# Altium schematic sheet Stepper Motor4.SchDoc
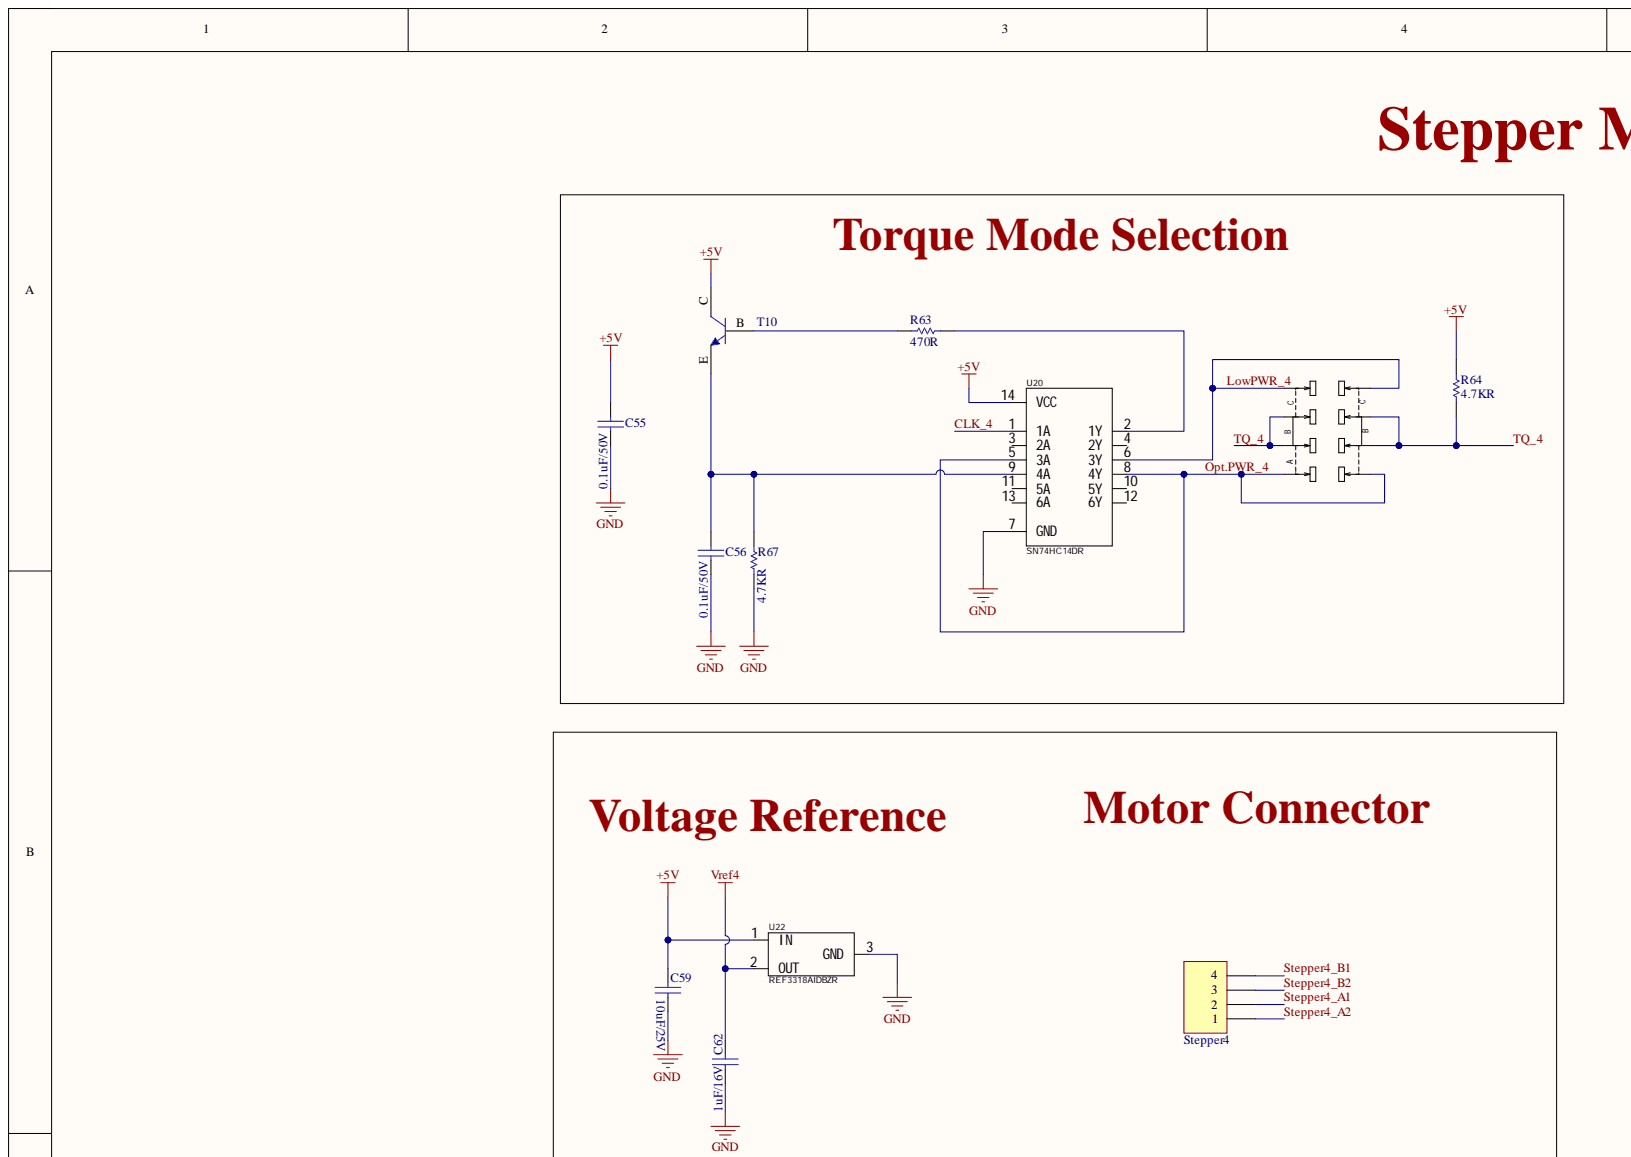
[diagram p0: Stepper Motor4.SchDoc, top left]
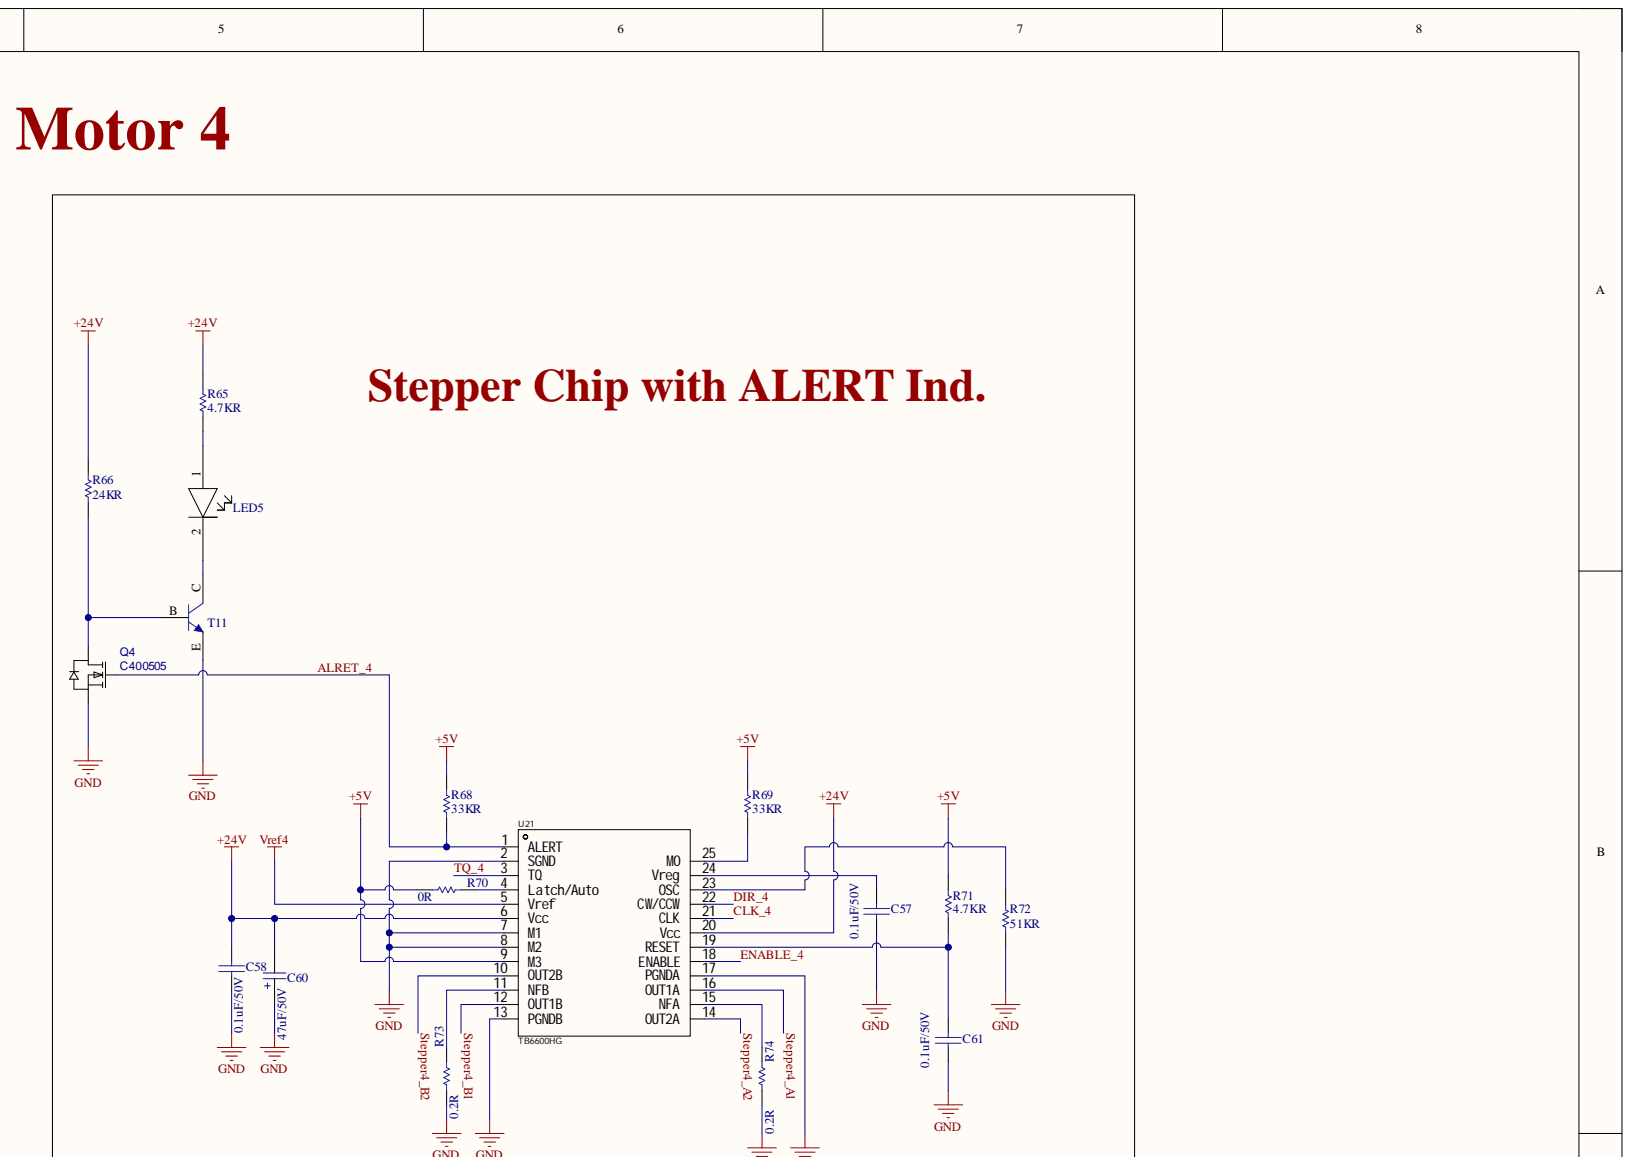
[diagram p1: Stepper Motor4.SchDoc, top right]
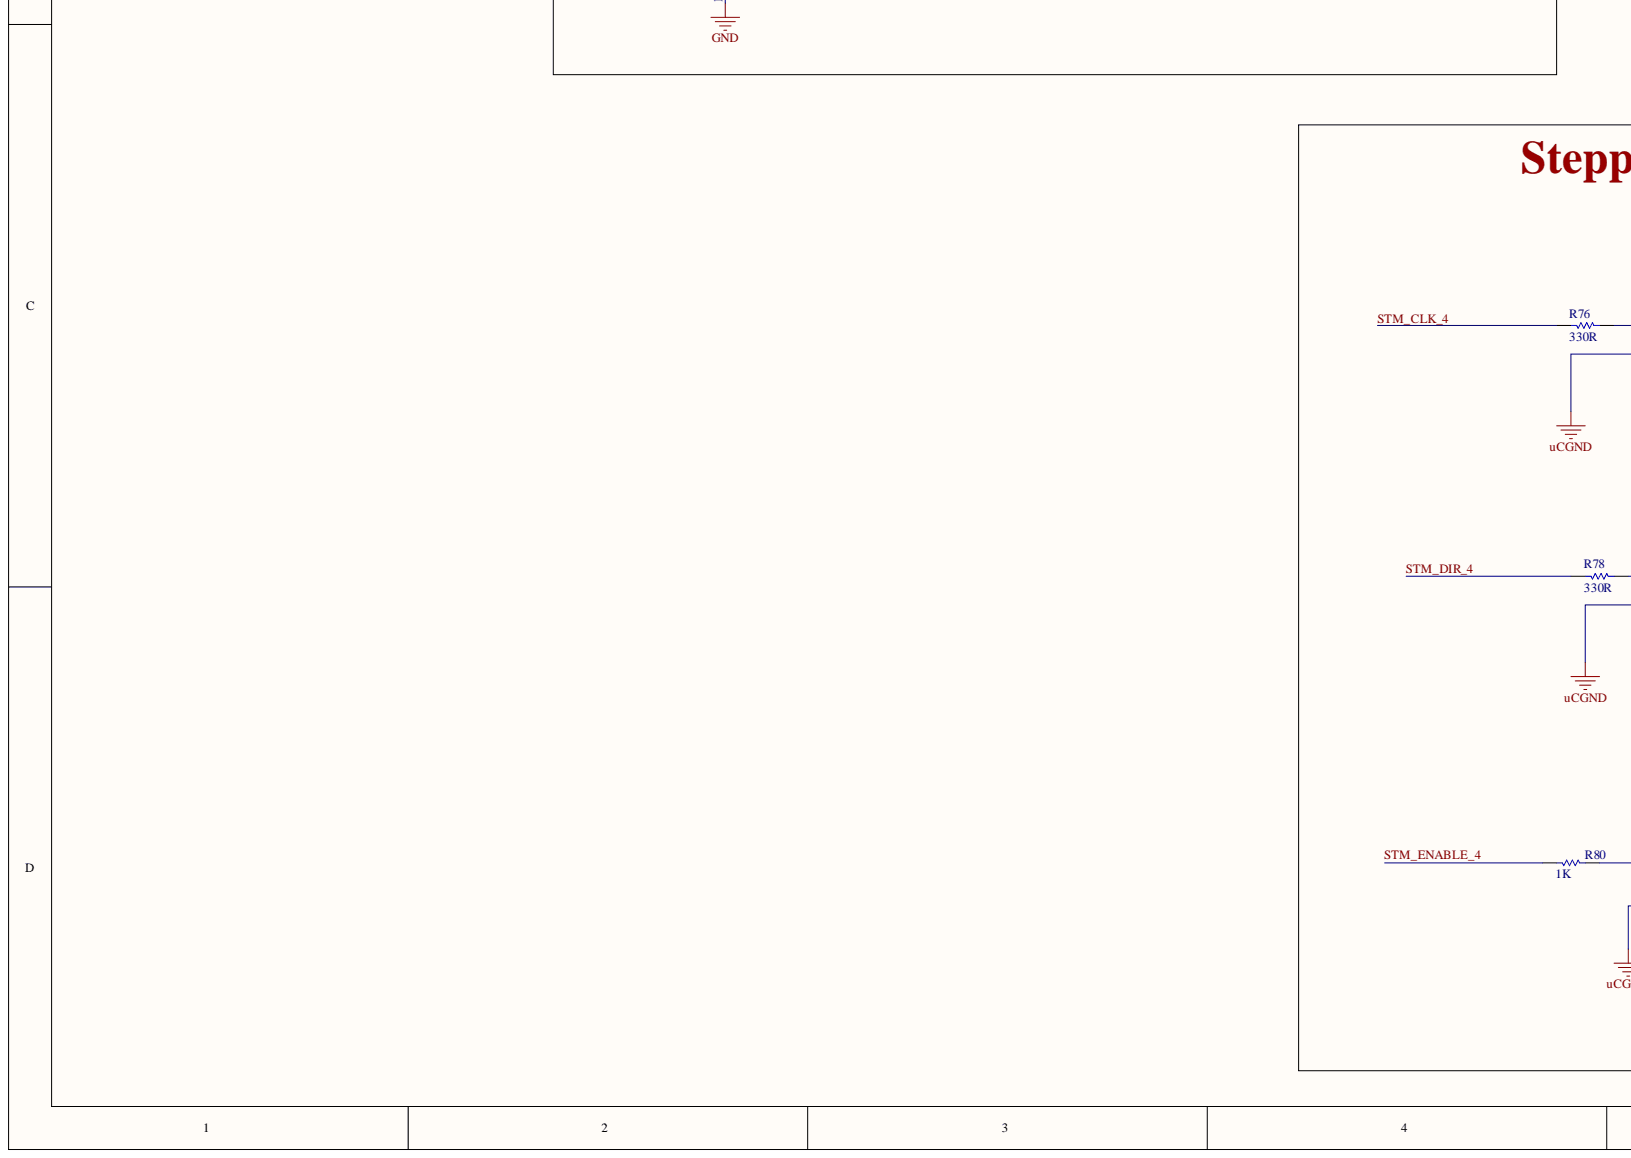
[diagram p2: Stepper Motor4.SchDoc, bottom left]
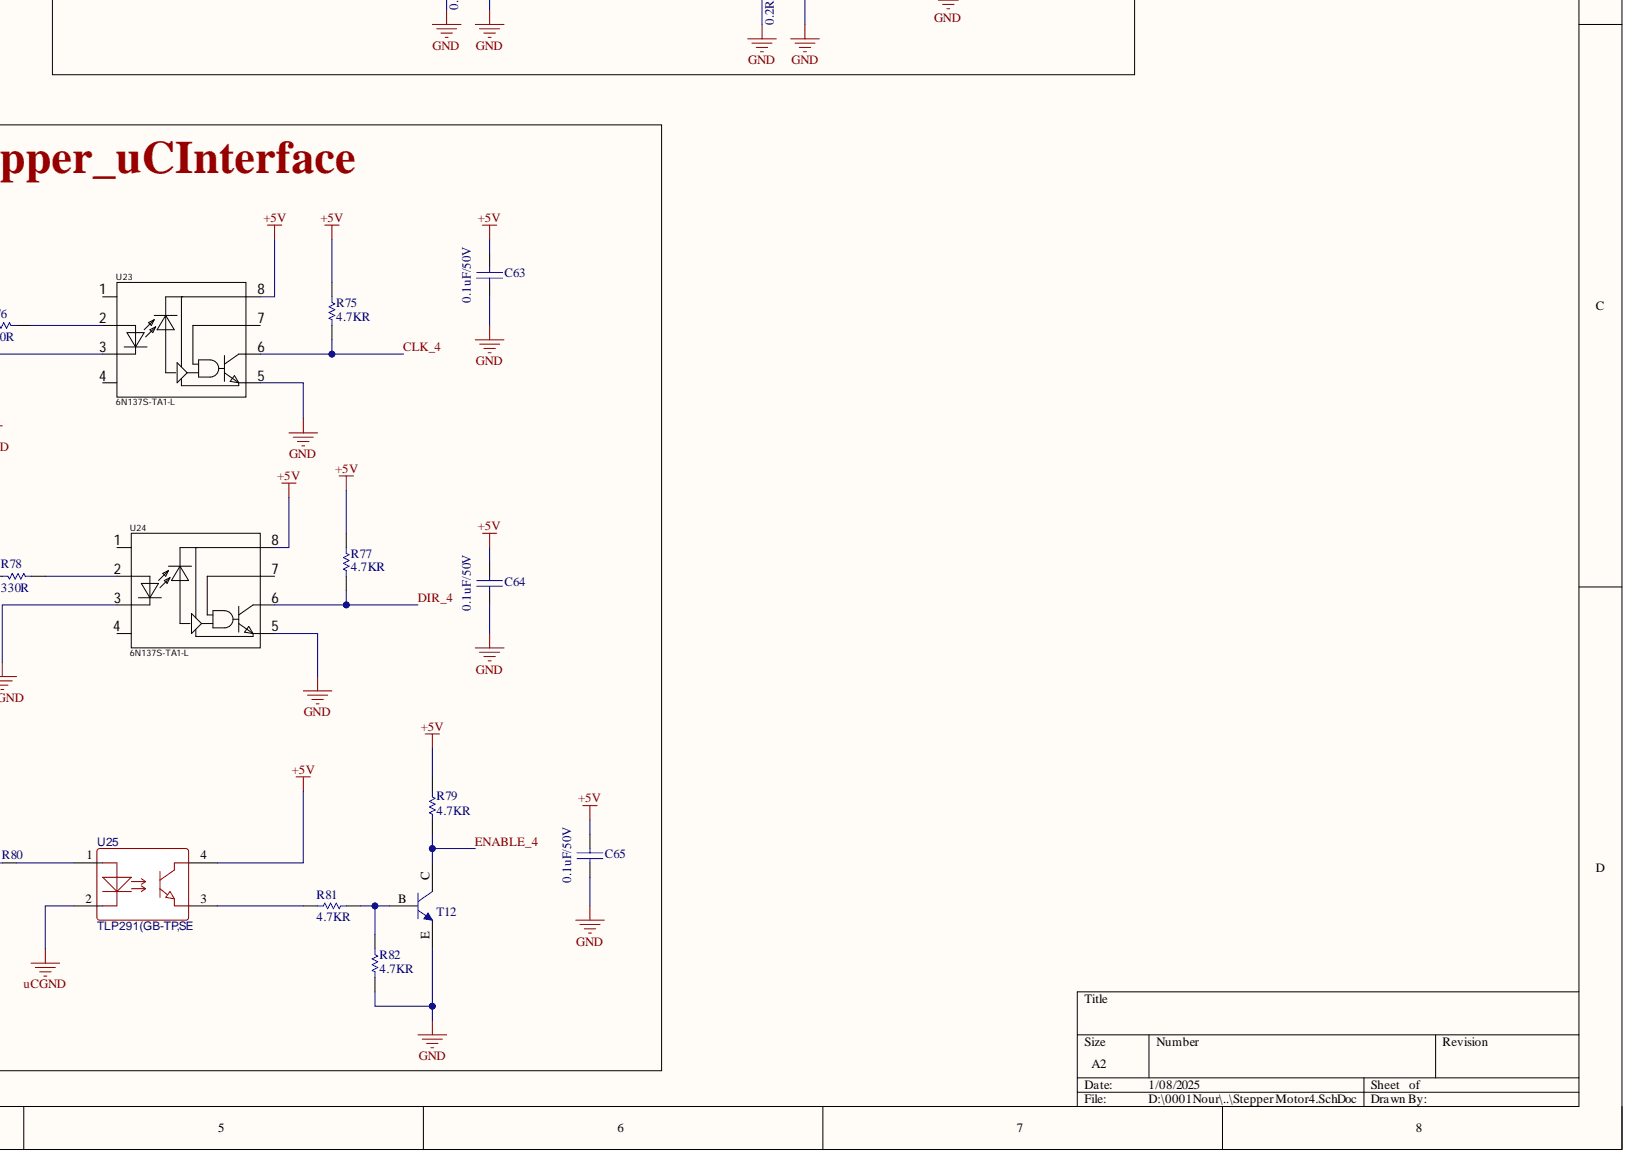
[diagram p3: Stepper Motor4.SchDoc, bottom right]

## Parts
C55  0.1uF/50V  [PCBComponent_1]
C56  0.1uF/50V  [PCBComponent_1]
C57  0.1uF/50V  [PCBComponent_1]
C58  0.1uF/50V  [PCBComponent_1]
C59  10uF/25V  [PCBComponent_1]
C60  47uF/50V  [CAPLEAD_D6.3mm]
C61  0.1uF/50V  [PCBComponent_1]
C62  1uF/16V  [PCBComponent_1]
C63  0.1uF/50V  [PCBComponent_1]
C64  0.1uF/50V  [PCBComponent_1]
C65  0.1uF/50V  [PCBComponent_1]
LED5  1206 LED  [1206 LED]  (value not drawn)
Q4  C400505  [SOT-23-3_L2.9-W1.3-P1.90-LS2.4-BR]
R63  470R  [RESC1608X06N]
R64  4.7KR  [R0402]
R65  4.7KR  [R0402]
R66  24KR  [RESC1608X06N]
R67  4.7KR  [R0402]
R68  33KR  [RESC1608X06N]
R69  33KR  [RESC1608X06N]
R70  0R  [RESC1608X06N]
R71  4.7KR  [R0402]
R72  51KR  [R0402]
R73  0.2R  [RESC6431X12N]
R74  0.2R  [RESC6431X12N]
R75  4.7KR  [R0402]
R76  330R  [R0402]
R77  4.7KR  [R0402]
R78  330R  [R0402]
R79  4.7KR  [R0402]
R80  1K  [R0402]
R81  4.7KR  [R0402]
R82  4.7KR  [R0402]
Stepper4  Header 4 Pin Polar  [PCBComponent_1]  (value not drawn)
SW4  MST23D19G2  [SW-SMD_MST23D19G2]  (value not drawn)
T10  MMBT3904 Transistor  [MMBT3904]  (value not drawn)
T11  MMBT3904 Transistor  [MMBT3904]  (value not drawn)
T12  MMBT3904 Transistor  [MMBT3904]  (value not drawn)
U20  SN74HC14DR  [SOIC-14_L8.7-W3.9-P1.27-LS6.0-BL]
U21  TB6600HG  [HZIP25-P-1.00F]
U22  REF3318AIDBZR  [SOT-23-3_L2.9-W1.3-P1.90-LS2.4-BR]
U23  6N137S-TA1-L  [SOP-8_L9.8-W6.6-P2.54-LS10.3-BL]
U24  6N137S-TA1-L  [SOP-8_L9.8-W6.6-P2.54-LS10.3-BL]
U25  TLP291(GB-TP,SE  [SOP-4-1.27mm]

## Nets
+5V: C55.2 C59.2 C63.2 C64.2 C65.2 R64.1 R68.2 R69.2 R70.2 R71.1 R75.1 R77.1 R79.1 T10.C U20.14(VCC) U21.9(M3) U22.1 U23.8 U24.8 U25.4
+24V: C58.2 C60.2 R65.1 R66.2 U21.6(Vcc) U21.20(Vcc)
GND: C55.1 C56.1 C57.1 C58.1 C59.1 C60.1 C61.1 C62.1 C63.1 C64.1 C65.1 Q4.2 R67.2 R72.2 R73.1 R74.1 R82.2 T11.E T12.E U20.7(GND) U21.2(SGND) U21.7(M1) U21.8(M2) U21.13(PGNDB) U21.17(PGNDA) U22.3 U23.5 U24.5
uCGND: U23.3 U24.3 U25.2
Vref4: C62.2 U21.5(Vref) U22.2
ALRET_4: Q4.1 R68.1 U21.1(ALERT)
CLK_4: R75.2 U20.1(1A) U21.21(CLK) U23.6
DIR_4: R77.2 U21.22(CW/CCW) U24.6
ENABLE_4: R79.2 T12.C U21.18(ENABLE)
LowPWR_4: SW4.4 SW4.5 U20.6(3Y)
Opt.PWR_4: SW4.1 SW4.8 U20.5(3A) U20.8(4Y)
Stepper4_A1: Stepper4.2 U21.16(OUT1A)
Stepper4_A2: Stepper4.1 U21.14(OUT2A)
Stepper4_B1: Stepper4.4 U21.12(OUT1B)
Stepper4_B2: Stepper4.3 U21.10(OUT2B)
STM_CLK_4: R76.2
STM_DIR_4: R78.2
STM_ENABLE_4: R80.2
TQ_4: R64.2 SW4.2 SW4.3 SW4.6 SW4.7 U21.3(TQ)
NetC56_2: C56.2 R67.1 T10.E U20.9(4A)
NetC57_2: C57.2 U21.24(Vreg)
NetC61_2: C61.2 R71.2 U21.19(RESET)
NetLED5_1: LED5.1 R65.2
NetLED5_2: LED5.2 T11.C
NetQ4_3: Q4.3 R66.1 T11.B
NetR63_1: R63.1 U20.2(1Y)
NetR63_2: R63.2 T10.B
NetR69_1: R69.1 U21.25(MO)
NetR70_1: R70.1 U21.4(Latch/Auto)
NetR72_1: R72.1 U21.23(OSC)
NetR73_2: R73.2 U21.11(NFB)
NetR74_2: R74.2 U21.15(NFA)
NetR76_1: R76.1 U23.2
NetR78_1: R78.1 U24.2
NetR80_1: R80.1 U25.1
NetR81_1: R81.1 R82.1 T12.B
NetR81_2: R81.2 U25.3

Not connected: U20 3(2A) 4(2Y) 10(5Y) 11(5A) 12(6Y) 13(6A); U23 1 4 7; U24 1 4 7

## Library data of the parts
C55-C58 C61 C63-C65 = 0603 Capacitor: C1591; Component Kind: Standard; DataSheet Link: https://eu.mouser.com/datasheet/2/400/lcc_automotive_general_en-843974.pdf; Library Name: 0603 Capacitor.IntLib; Mouser Link: https://eu.mouser.com/ProductDetail/TDK/CGA1A2X7R1H331M030BA?qs=sGAEpiMZZMs0AnBnWHyRQL8L3bkL%252bvtyS9XpSB1mk7rVV5K8%252bbxEeg%3d%3d; Created By: NourAldin
C59 = 0603 Capacitor: C96446; Component Kind: Standard; DataSheet Link: https://eu.mouser.com/datasheet/2/400/lcc_automotive_general_en-843974.pdf; Library Name: 0603 Capacitor.IntLib; Mouser Link: https://eu.mouser.com/ProductDetail/TDK/CGA1A2X7R1H331M030BA?qs=sGAEpiMZZMs0AnBnWHyRQL8L3bkL%252bvtyS9XpSB1mk7rVV5K8%252bbxEeg%3d%3d; Created By: NourAldin
C60 = A759EQ475M2AAAE160: C45100; Manufacturer: KEMET; Category: Polymer Capacitors; Component Kind: Standard; ComponentLink1Description: http://www.kemet.com/docfinder?Partnumber=A759EQ475M2AAAE160&src-supplier=Digikey; ComponentLink1URL: http://www.kemet.com/docfinder?Partnumber=A759EQ475M2AAAE160&src-supplier=Digikey; ComponentLink2Description: http://www.farnell.com/datasheets/2095614.pdf?src-supplier=Element14; ComponentLink2URL: http://www.farnell.com/datasheets/2095614.pdf?src-supplier=Element14; Library Name: GlobalLib.IntLib; Manufacturer Part Number: A759EQ475M2AAAE160; Pricing 1: 1=0.97, 10=0.73, 50=0.73, 100=0.546, 1000=0.384, 10000=0.326 (USD); RoHS: Yes; Stock 1: 17219; Supplier 1: Mouser; Supplier Part Number 1: 80-A759EQ475M2AAE160
C62 = 0603 Capacitor: C1592; Component Kind: Standard; DataSheet Link: https://eu.mouser.com/datasheet/2/400/lcc_automotive_general_en-843974.pdf; Library Name: 0603 Capacitor.IntLib; Mouser Link: https://eu.mouser.com/ProductDetail/TDK/CGA1A2X7R1H331M030BA?qs=sGAEpiMZZMs0AnBnWHyRQL8L3bkL%252bvtyS9XpSB1mk7rVV5K8%252bbxEeg%3d%3d; Created By: NourAldin
Q4 = BSS138_MOSFET: Manufacturer: YANGJIE(扬杰); Manufacturer Part: BSS138; Contributor: LCSC; Component Kind: Standard; Description: C400505; JLCPCB Part Class: Extended Part; spicePre: Q; spiceSymbolName: BSS138_C400505; Supplier: LCSC; Supplier Part: C400505; Library Name: DIVERSE.IntLib
R63 R66 R68-R70 = 0603 Resistor: Component Kind: Standard; DataSheet Link: https://eu.mouser.com/datasheet/2/315/AOA0000C307-1149632.pdf; Description: 0603 Resistor; Library Name: 0603 Resistor.IntLib; Mouser Link: https://eu.mouser.com/ProductDetail/Panasonic/ERA-3AHD180V?qs=sGAEpiMZZMvdGkrng054t5mej2KPdPuFSlDBd1tSiF0%3d; Created By: NourAldin
R64 R65 R67 R71 R72 R75 R77 R79 R81 R82 = 0402 Resistor: C105871; Component Kind: Standard; Library Name: DIVERSE.IntLib
R73 R74 = 2512 Resistor: C2903473; Component Kind: Standard; DataSheet Link: https://eu.mouser.com/datasheet/2/54/ss2h-2512-1302652.pdf; Library Name: 2512 Resistor.IntLib; Mouser Link: https://eu.mouser.com/ProductDetail/Bourns/CSS2H-2512K-2L00F?qs=sGAEpiMZZMukHu%252bjC5l7YewuupLM8jZrvWs15Y6t0rY%3D&fbclid=IwAR0ZD_uc1Y03YG_KyYG8NxrpBzYgFzVeevvjxrlqtGWJ1HKz-jrYfqlFWCw; Created By: NourAldin
R76 R78 = 0402 Resistor: C105875; Component Kind: Standard; Library Name: DIVERSE.IntLib
R80 = 0402 Resistor: C106235; Component Kind: Standard; Library Name: DIVERSE.IntLib
SW4 = MST23D19G2: Manufacturer: SHOU HAN(首韩); Add into BOM: yes; Circuit: 双刀三掷; Current Rating (DC): 100mA; Mechanical Life: 1万次; Pin Style: SMDSplicing; Switch Height: 3.5mm; Switch Length: 12.95mm; Switch Width: 3.55mm; Voltage Rating (DC): 12V; Actuator Color: -; Actuator Style: -; Component Kind: Standard; Convert to PCB: yes; Current Rating (AC): -; Datasheet: https://atta.szlcsc.com/upload/public/pdf/source/20190925/C431545_A88710AC241B275593C257CF6D595AD7.pdf; Device: MST23D19G2; JLCPCB Part Class: Extended Part; LCSC Part Name: 三档立式贴片 8脚拨动 柄长2.0MM; Library Name: LIB.SchLib; Manufacturer Part: MST23D19G2; Mounting Style: Brick nogging; Operating Temperature: -25℃~+70℃; Supplier: LCSC; Supplier Footprint: SMD; Supplier Part: C431545; Symbol: MST23D19G2; Voltage Rating (AC): -
U20 = SN74HC14DR: C6820; Manufacturer: TI(德州仪器); Add into BOM: yes; High level range (VIH): 1.5V~4.2V; Input Type: Schmitt Trigger; Low level range (VIL): 300mV~1.2V; Max Propagation Delay: 21ns@6V,50pF; Number of Circuits: 6; Number of Inputs: 1; Sink Current: 5.2mA; Supply Current (Max): 2uA; Supply Voltage(Single): 2V~6V; Component Kind: Standard; Convert to PCB: yes; Datasheet: https://www.ti.com/cn/lit/gpn/sn74hc14; Device: SN74HC14DR; JLCPCB Part Class: Extended Part; LCSC Part Name: 六路施密特触发反相器; Library Name: LIB.SchLib; Manufacturer Part: SN74HC14DR; Operating Temperature: -40℃~+85℃; Series: 74HCSeries; Source Current: 5.2mA; Supplier: LCSC; Supplier Footprint: SOIC-14; Supplier Part: C6820; Symbol: SN74HC14DR
U21 = TB6600HG: C66042; Manufacturer: TOSHIBA(东芝); Add into BOM: yes; Component Kind: Standard; Convert to PCB: yes; Datasheet: https://atta.szlcsc.com/upload/public/pdf/source/20170511/1494500127812.pdf; Device: TB6600HG; JLCPCB Part Class: Extended Part; LCSC Part Name: 4.5A 42V电机驱动芯片; Library Name: LIB.SchLib; Manufacturer Part: TB6600HG; Supplier: LCSC; Supplier Footprint: HZIP-25-P-1mm; Supplier Part: C66042; Symbol: TB6600HG
U22 = REF3318AIDBZR: C115877; Manufacturer: TI(德州仪器); Add into BOM: yes; Input Voltage: 2V~5.5V; Noise - 1/10Hz to 10Hz: 50uVp-p; Output Current: 5mA; Output Type: Fixed; Output Voltage: 1.8V; Reference Type: series connection; Supply Current: 8.5uA; Temperature Coefficient: 30ppm/℃; Tolerance: ±0.15%; Component Kind: Standard; Convert to PCB: yes; Datasheet: https://www.ti.com/cn/lit/gpn/ref3318; Device: REF3318AIDBZR; JLCPCB Part Class: Extended Part; LCSC Part Name: REF3318AIDBZR; Library Name: LIB.SchLib; Manufacturer Part: REF3318AIDBZR; Noise - 10Hz to 10kHz: -; Operating Temperature: -40℃~+125℃@(Ta); Supplier: LCSC; Supplier Footprint: SOT-23; Supplier Part: C115877; Symbol: REF3318AIDBZR
U23 U24 = 6N137S-TA1-L: C92651; Manufacturer: LITEON(光宝); Add into BOM: yes; Common Mode Transient Immunity (CMTI): 10kV/us; Data Rate: 15Mbit/s; Input Type: DC; Number of Channels: 1; Propagation Delay tpLH/tpHL: 32ns；40ns; Supply Voltage: 4.5V~5.5V; Component Kind: Standard; Convert to PCB: yes; Datasheet: https://atta.szlcsc.com/upload/public/pdf/source/20191018/C92651_D1F9F6F1F10C7EC7C3739E5ECB700036.pdf; Device: 6N137S-TA1-L; JLCPCB Part Class: Extended Part; LCSC Part Name: DC输入 1通道; Library Name: LIB.SchLib; Manufacturer Part: 6N137S-TA1-L; Operating Temperature: -40℃~+85℃; Supplier: LCSC; Supplier Footprint: SOP-8-2.54mm; Supplier Part: C92651; Symbol: 6N137S-TA1
U25 = TLP291(GB-TP,SE(T: C55144; BOM_Manufacturer: TOSHIBA(东芝); BOM_JLCPCB Part Class: Extended Part; BOM_Supplier: LCSC; Component Kind: Standard; LCSC Link: https://www.lcsc.com/product-detail/Optocouplers-Phototransistor-Output_TOSHIBA-TLP291-GB-TP-SE_C55144.html; Library Name: CO2_Lib.IntLib; Manufacturer Part Number: TLP291(GB-TP,SE; spiceSymbolName: TLP291(GB-TP,SE(T; Supplier Part Number 2: C55144
LED5 = 1206 LED: Component Kind: Standard; Description: 1206 LED; Library Name: 1206 LED.IntLib; Created By: NourAldin
Stepper4 = Header 4 Pin Polar: Component Kind: Standard; Description: Header 4 Pin Polar; Library Name: Header 4 Pin Polar.IntLib
T10-T12 = MMBT3904 Transistor: Component Kind: Standard; Description: MMBT3904 Transistor; Library Name: MMBT3904 Transistor.IntLib; Created By: NourAldin
